# Altium schematic sheet LM-008.SchDoc(LM-008)
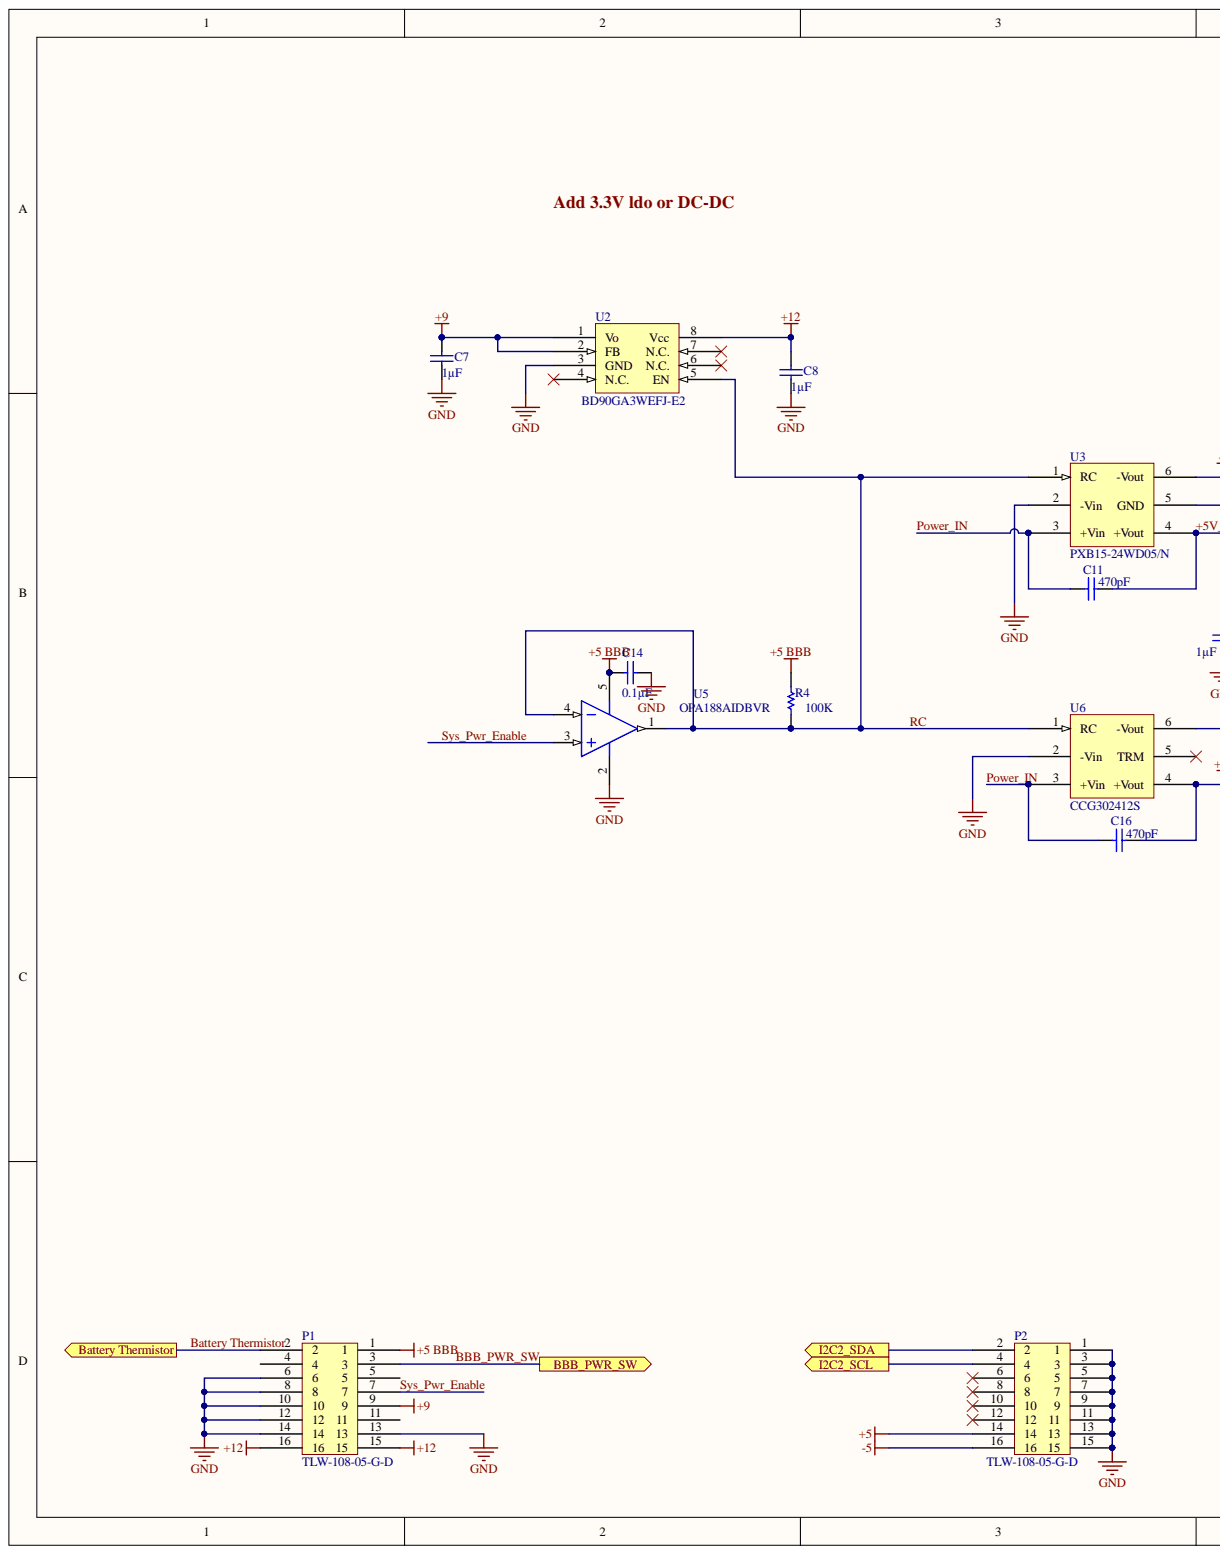
[diagram p0: LM-008.SchDoc, left]
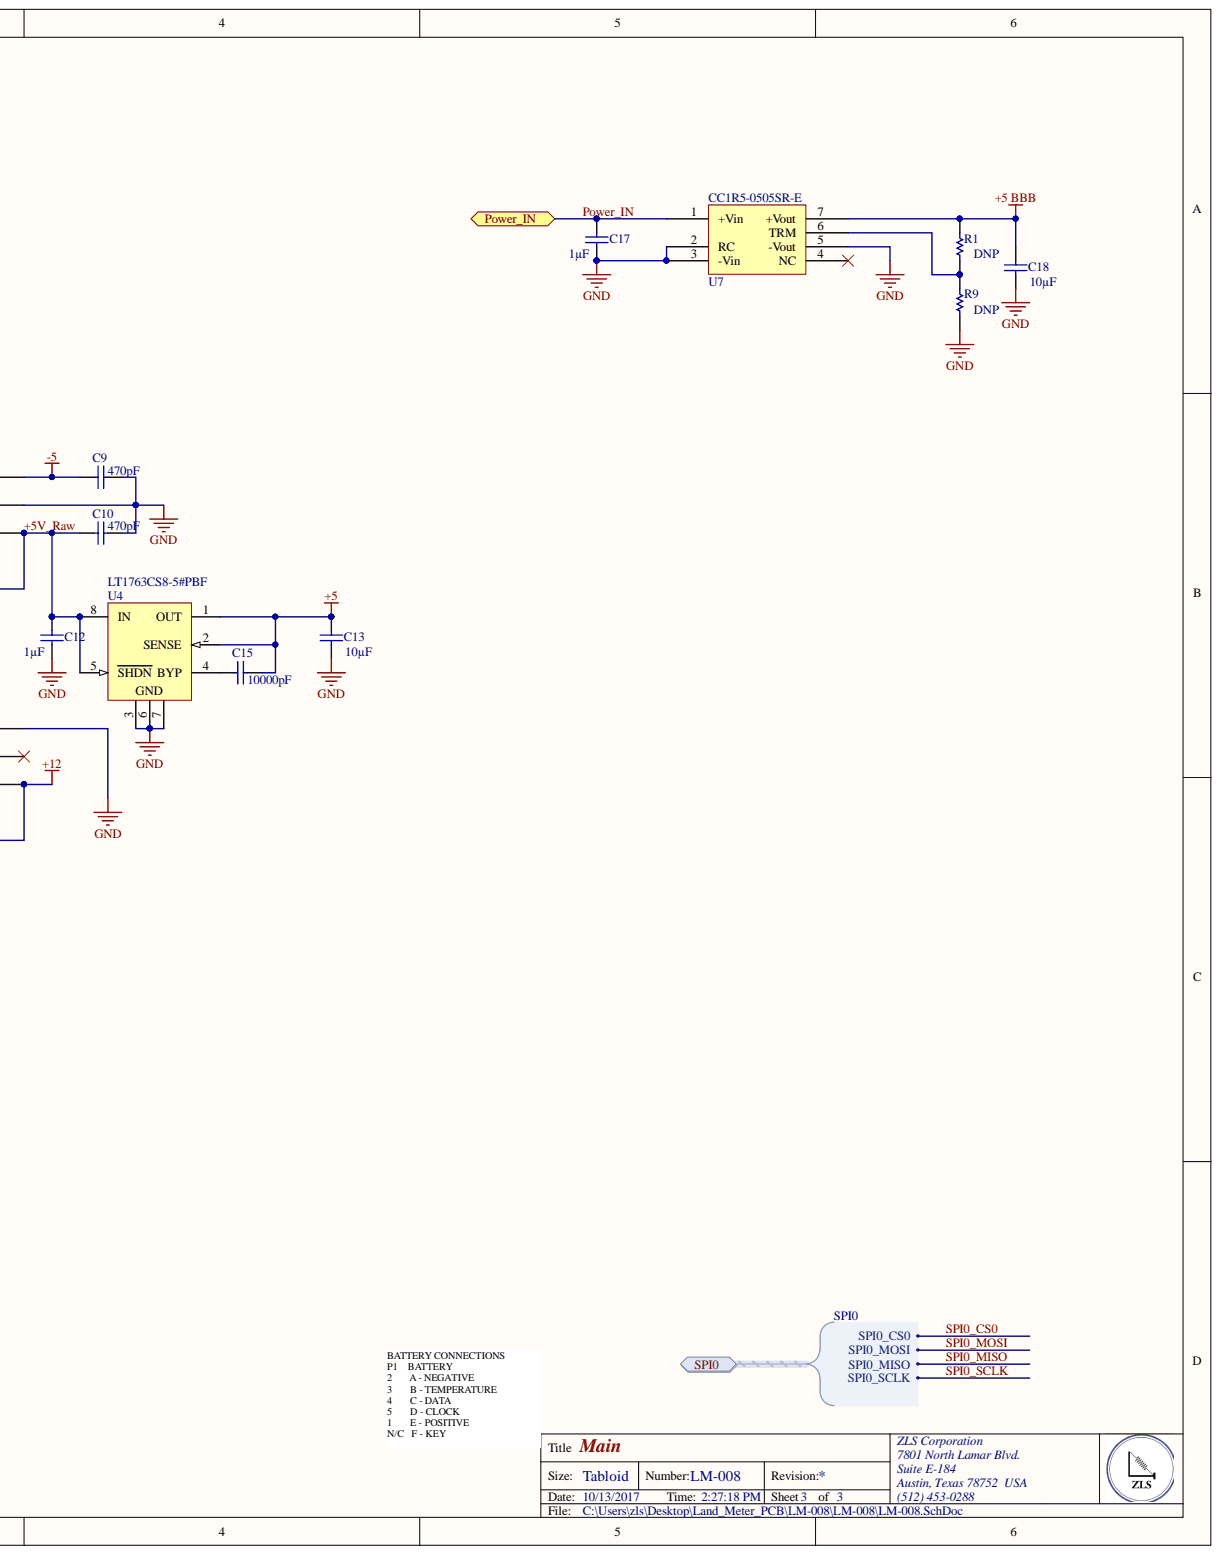
[diagram p1: LM-008.SchDoc, right]

## Parts
C7  Capacitance: 1µF  [CAPC1206(3216)130_N]  (hidden comment: CAP CER 1UF 50V X7R 1210)
C8  Capacitance: 1µF  [CAPC1206(3216)130_N]  (hidden comment: CAP CER 1UF 50V X7R 1210)
C9  Capacitance: 470pF  [CAPC1812(4532)230_M]  (hidden comment: CAP CER 470PF 3KV X7R 1812)
C10  Capacitance: 470pF  [CAPC1812(4532)230_M]  (hidden comment: CAP CER 470PF 3KV X7R 1812)
C11  Capacitance: 470pF  [CAPC1812(4532)230_M]  (hidden comment: CAP CER 470PF 3KV X7R 1812)
C12  Capacitance: 1µF  [CAPC1210(3225)100_L]  (hidden comment: CAP CER 1UF 50V X7R 1210)
C13  Capacitance: 10µF  [CAPC0805(2012)145_N]  (hidden comment: CAP CER 10UF 10V X7R 0805)
C14  Capacitance: 0.1µF  [CAPC0805(2012)145_N]  (hidden comment: CAP CER 0.1UF 16V X7R 0805)
C15  Capacitance: 10000pF  [CAPC0805(2012)145_N]  (hidden comment: CAP CER 10000PF 25V X7R 0805)
C16  Capacitance: 470pF  [CAPC1812(4532)230_M]  (hidden comment: CAP CER 470PF 3KV X7R 1812)
C17  Capacitance: 1µF  [CAPC1210(3225)100_L]  (hidden comment: CAP CER 1UF 50V X7R 1210)
C18  Capacitance: 10µF  [CAPC0805(2012)145_N]  (hidden comment: CAP CER 10UF 10V X7R 0805)
P1  TLW-108-05-G-D  [TLW-108-05-G-D]
P2  TLW-108-05-G-D  [TLW-108-05-G-D]
R1  DNP  [RESC0402(1005)_L]
R4  100K  [RESC0402(1005)_L]
R9  DNP  [RESC0402(1005)_L]
U2  BD90GA3WEFJ-E2  [TSOP127P600X100-8N]
U3  PXB15-24WD05/N  [PXB15-24WD05/N]
U4  LT1763CS8-5#PBF  [LT-S8-8_M]
U5  OPA188AIDBVR  [DBV0005A_M]
U6  CCG302412S  [CCG30]
U7  CC1R5-0505SR-E  [CC1R5-0505SR-E]

## Ports
Battery Thermistor, BBB_PWR_SW, I2C2_SCL, I2C2_SDA, Power_IN, SPI0, SPI0.SPI0_CS0, SPI0.SPI0_MISO, SPI0.SPI0_MOSI, SPI0.SPI0_SCLK

## Nets
+5: C13.2 C15.1 P2.14 U4.1(OUT) U4.2(SENSE)
+5 BBB: C14.1 C18.2 P1.1 R1.2 R4.2 U5.5 U7.7(+Vout)
+9: C7.2 P1.9 U2.1(Vo) U2.2(FB)
+12: C8.2 C16.2 P1.15 P1.16 U2.8(Vcc) U6.4(+Vout)
-5: C9.1 P2.16 U3.6(-Vout)
GND: C7.1 C8.1 C9.2 C10.2 C12.1 C13.1 C14.2 C17.1 C18.1 P1.6 P1.8 P1.10 P1.12 P1.13 P1.14 P2.1 P2.3 P2.5 P2.7 P2.9 P2.11 P2.13 P2.15 R9.1 U2.3(GND) U3.2(-Vin) U3.5(GND) U4.3 U4.6 U4.7 U5.2 U6.2(-Vin) U6.6(-Vout) U7.2(RC) U7.3(-Vin) U7.5(-Vout)
+5V_Raw: C10.1 C11.2 C12.2 U3.4(+Vout) U4.5(SHDN) U4.8(IN)
Battery Thermistor: P1.2 ; port Battery Thermistor
BBB_PWR_SW: P1.3 ; port BBB_PWR_SW
I2C2_SCL: P2.4 ; port I2C2_SCL
I2C2_SDA: P2.2 ; port I2C2_SDA
Power_IN: C11.1 C16.1 C17.2 U3.3(+Vin) U6.3(+Vin) U7.1(+Vin) ; port Power_IN
RC: R4.1 U2.5(EN) U3.1(RC) U5.1 U5.4 U6.1(RC)
Sys_Pwr_Enable: P1.7 U5.3
NetC15_2: C15.2 U4.4(BYP)
NetR1_1: R1.1 R9.2 U7.6(TRM)
SPI0_CS0: ; port SPI0
SPI0_MISO: ; port SPI0
SPI0_MOSI: ; port SPI0
SPI0_SCLK: ; port SPI0

Not connected: P1 4 5 11; P2 6 8 10 12; U2 4(N.C.) 6(N.C.) 7(N.C.); U6 5(TRM); U7 4(NC)

## Library data of the parts
C7 C8 = CMP-1037-04913-1: Manufacturer Part Number: GRM32RR71H105KA01L; Manufacturer: Murata Electronics North America; Tolerance: ±10%; Voltage - Rated: 50V; Thickness (Max): 0.079" (2.00mm); Temperature Coefficient: X7R; Supplier Part Number 1: 490-1863-6-ND; Supplier 1: Digi-Key; Stock 1: 66890; Size / Dimension: 0.126" L x 0.098" W (3.20mm x 2.50mm); RoHS: RoHS Compliant; Ratings: -; Pricing 1: 1=0.35, 10=0.242, 100=0.1449, 500=0.10868 (USD); Part Status: Active; Packaging: Digi-Reel®; Package / Case: 1210 (3225 Metric); Operating Temperature: -55°C ~ 125°C; Mounting Type: Surface Mount, MLCC; Library Name: Altium Content Vault; Lead Style: -; Lead Spacing: -; Height - Seated (Max): -; Features: -; Description: CAP CER 1UF 50V X7R 1210; ComponentLink1URL: http://search.murata.co.jp/Ceramy/image/img/A01X/G101/ENG/GRM32RR71H105KA01-01.pdf; ComponentLink1Description: http://search.murata.co.jp/Ceramy/image/img/A01X/G101/ENG/GRM32RR71H105KA01-01.pdf; Component Kind: Standard; Category: Capacitors; Applications: General Purpose
C9-C11 C16 = CMP-1040-00561-1: Manufacturer Part Number: C1812C471KHRACTU; Manufacturer: Kemet; Tolerance: 10%; Width: 0.126inch; Voltage Rating (DC): 3kV; Voltage Rating: 3kV; Thickness: 0.071inch; Supplier Part Number 1: 399-7289-6-ND; Supplier 1: Digi-Key; Stock 1: 2705; Pricing 1: 1=0.88, 10=0.666, 100=0.4761 (USD); Packaging: Tape and Reel; Package Quantity: 1; Min Operating Temperature: -55°C; Max Operating Temperature: 125°C; Library Name: Altium Content Vault; Length: 0.177inch; Lead Free: Lead Free; Description: CAP CER 470PF 3KV X7R 1812; ComponentLink1URL: http://www.kemet.com/docfinder?Partnumber=C1812C471KHRACTU; ComponentLink1Description: http://www.kemet.com/docfinder?Partnumber=C1812C471KHRACTU; Component Kind: Standard; Case Code (Metric): 4532; Case Code (Imperial): 1812; Case/Package: 1812
C12 C17 = CMP-1038-00632-1: Manufacturer Part Number: GRM32RR71H105KA01L; Manufacturer: Murata Electronics North America; Tolerance: ±10%; Voltage - Rated: 50V; Thickness (Max): 0.079" (2.00mm); Temperature Coefficient: X7R; Supplier Part Number 1: 490-1863-6-ND; Supplier 1: Digi-Key; Stock 1: 66890; Size / Dimension: 0.126" L x 0.098" W (3.20mm x 2.50mm); RoHS: RoHS Compliant; Ratings: -; Pricing 1: 1=0.35, 10=0.242, 100=0.1449, 500=0.10868 (USD); Part Status: Active; Packaging: Digi-Reel®; Package / Case: 1210 (3225 Metric); Operating Temperature: -55°C ~ 125°C; Mounting Type: Surface Mount, MLCC; Library Name: Altium Content Vault; Lead Style: -; Lead Spacing: -; Height - Seated (Max): -; Features: -; Description: CAP CER 1UF 50V X7R 1210; ComponentLink1URL: http://search.murata.co.jp/Ceramy/image/img/A01X/G101/ENG/GRM32RR71H105KA01-01.pdf; ComponentLink1Description: http://search.murata.co.jp/Ceramy/image/img/A01X/G101/ENG/GRM32RR71H105KA01-01.pdf; Component Kind: Standard; Category: Capacitors; Applications: General Purpose
C13 C18 = CMP-1036-04795-1: Manufacturer Part Number: GCM21BR71A106KE22L; Manufacturer: Murata Electronics North America; Tolerance: ±10%; Voltage - Rated: 10V; Thickness (Max): 0.055" (1.40mm); Temperature Coefficient: X7R; Supplier Part Number 1: 490-10674-6-ND; Supplier 1: Digi-Key; Stock 1: 136726; Size / Dimension: 0.079" L x 0.049" W (2.00mm x 1.25mm); RoHS: RoHS Compliant; Ratings: AEC-Q200; Pricing 1: 1=0.49, 10=0.347, 100=0.2282, 500=0.16906, 1000=0.14369 (USD); Part Status: Active; Packaging: Digi-Reel®; Package / Case: 0805 (2012 Metric); Operating Temperature: -55°C ~ 125°C; Mounting Type: Surface Mount, MLCC; Library Name: Altium Content Vault; Lead Style: -; Lead Spacing: -; Height - Seated (Max): -; Features: -; Description: CAP CER 10UF 10V X7R 0805; ComponentLink1URL: http://search.murata.co.jp/Ceramy/image/img/A01X/G101/ENG/GCM21BR71A106KE22-01.pdf; ComponentLink1Description: http://search.murata.co.jp/Ceramy/image/img/A01X/G101/ENG/GCM21BR71A106KE22-01.pdf; Component Kind: Standard; Category: Capacitors; Applications: Automotive
C14 = CMP-1036-04795-1: Manufacturer Part Number: GRM219R71C104KA01D; Manufacturer: Murata Electronics North America; Voltage - Rated: 25V; Tolerance: ±10%; Thickness (Max): 0.053" (1.35mm); Temperature Coefficient: X7R; Supplier Part Number 2: 490-1683-6-ND; Supplier Part Number 1: 490-1673-6-ND; Supplier 2: Digi-Key; Stock 2: 245331; Size / Dimension: 0.079" L x 0.049" W (2.00mm x 1.25mm); RoHS: RoHS Compliant; Ratings: -; Pricing 2: 1=0.14, 10=0.098, 100=0.0462, 500=0.0324, 1000=0.02683 (USD); Part Status: Active; Packaging: Digi-Reel®; Package / Case: 0805 (2012 Metric); Operating Temperature: -55°C ~ 125°C; Mounting Type: Surface Mount, MLCC; Library Name: Altium Content Vault; Lead Style: -; Lead Spacing: -; Height - Seated (Max): -; Features: -; Description: CAP CER 0.1UF 16V X7R 0805; ComponentLink1URL: http://www.murata.com/~/media/webrenewal/support/library/catalog/products/capacitor/mlcc/c02e.ashx; ComponentLink1Description: http://www.murata.com/~/media/webrenewal/support/library/catalog/products/capacitor/mlcc/c02e.ashx; Component Kind: Standard; Category: Capacitors; Applications: General Purpose
C15 = CMP-1036-04795-1: Manufacturer Part Number: GRM216R71E103KA01D; Manufacturer: Murata Electronics North America; Tolerance: ±10%; Voltage - Rated: 25V; Thickness (Max): 0.028" (0.70mm); Temperature Coefficient: X7R; Supplier Part Number 1: 490-12748-6-ND; Supplier 1: Digi-Key; Stock 1: 13127; Size / Dimension: 0.079" L x 0.049" W (2.00mm x 1.25mm); RoHS: RoHS Compliant; Ratings: -; Pricing 1: 1=0.11, 10=0.077, 100=0.0343, 500=0.02452, 1000=0.01926 (USD); Part Status: Active; Packaging: Digi-Reel®; Package / Case: 0805 (2012 Metric); Operating Temperature: -55°C ~ 125°C; Mounting Type: Surface Mount, MLCC; Library Name: Altium Content Vault; Lead Style: -; Lead Spacing: -; Height - Seated (Max): -; Features: -; Description: CAP CER 10000PF 25V X7R 0805; ComponentLink1URL: http://www.murata.com/~/media/webrenewal/support/library/catalog/products/capacitor/mlcc/c02e.ashx; ComponentLink1Description: http://www.murata.com/~/media/webrenewal/support/library/catalog/products/capacitor/mlcc/c02e.ashx; Component Kind: Standard; Category: Capacitors; Applications: General Purpose
P1 P2 = TLW-108-05-G-D: CONN HEADER .100" 16POS DL GOLD; Manufacturer: Samtec Inc.; Style: Board to Board or Cable; Shrouding: Unshrouded; Row Spacing - Mating: 0.100" (2.54mm); Overall Contact Length: 0.335" (8.51mm); Number of Positions Loaded: All; Number of Positions: 16; Current Rating: 5.2A per Contact; Contact Length - Post: 0.170" (4.32mm); Contact Length - Mating: 0.105" (2.67mm); Voltage Rating: -; Termination: Solder; Supplier Part Number 1: SAM1094-08-ND; Supplier 1: Digi-Key; Stock 1: 0; RoHS: RoHS Compliant; Pricing 1: 1=4.48, 10=4.006, 100=3.2987, 500=2.60756, 1000=2.23842, 5000=2.04207, 10000=1.96353 (USD); Pitch - Mating: 0.100" (2.54mm); Part Status: Active; Packaging: Bulk; Operating Temperature: -55°C ~ 125°C; Number of Rows: 2; Mounting Type: Through Hole; Material Flammability Rating: -; Mated Stacking Heights: -; Manufacturer Part Number: TLW-108-05-G-D; Insulation Material: Liquid Crystal Polymer (LCP); Insulation Height: 0.060" (1.52mm); Insulation Color: Black; Ingress Protection: -; Features: -; Fastening Type: Push-Pull; Contact Type: Male Pin; Contact Shape: Square; Contact Material: Phosphor Bronze; Contact Finish Thickness - Post: Flash; Contact Finish Thickness - Mating: 10µin (0.25µm); Contact Finish - Post: Gold; Contact Finish - Mating: Gold; Connector Type: Header; ComponentLink1URL: http://suddendocs.samtec.com/prints/tlw-mkt.pdf; ComponentLink1Description: http://suddendocs.samtec.com/prints/tlw-mkt.pdf; Component Kind: Standard; Category: Connectors, Interconnects; Applications: -; Library Name: ZLS_Schematic_lib.SchLib
R1 R9 = CMP-1011-00927-1: TBD; Tolerance: ±1%; Temperature Coefficient: ±100ppm/°C; Supplier Part Number 1: YAG3220CT-ND; Supplier Device Package: 0402; Library Name: Altium Content Vault; Component Kind: Standard
R4 = CMP-1011-00927-1: Tolerance: ±1%; Temperature Coefficient: ±100ppm/°C; Supplier Part Number 1: YAG3220CT-ND; Supplier Device Package: 0402; Library Name: Altium Content Vault; Component Kind: Standard
U2 = BD90GA3WEFJ-E2: IC REG LDO 9V 0.3A 8HTSOP-J; Manufacturer: Rohm Semiconductor; Voltage - Input: Up to 14V; Voltage - Dropout (Typical): 0.6V @ 300mA; Regulator Topology: Positive Fixed; Current - Output: 300mA; Voltage - Output: 9V; Supplier Part Number 1: BD90GA3WEFJ-E2CT-ND; Supplier Device Package: 8-HTSOP-J; Supplier 1: Digi-Key; Stock 1: 4781; RoHS: RoHS Compliant; Pricing 1: 1=0.69, 10=0.606, 25=0.5364, 100=0.4672, 250=0.40664, 500=0.34608, 1000=0.27686 (USD); Part Status: Active; Packaging: Cut Tape (CT); Package / Case: 8-SOIC (0.154", 3.90mm Width) Exposed Pad; Operating Temperature: -25°C ~ 85°C; Mounting Type: Surface Mount; Manufacturer Part Number: BD90GA3WEFJ-E2; Current - Limit (Min): -; ComponentLink1URL: http://rohmfs.rohm.com/en/products/databook/datasheet/ic/power/linear_regulator/bdxxga3wefj-e.pdf; ComponentLink1Description: http://rohmfs.rohm.com/en/products/databook/datasheet/ic/power/linear_regulator/bdxxga3wefj-e.pdf; Component Kind: Standard; Category: Integrated Circuits (ICs); Library Name: ZLS_Schematic_lib.SchLib
U3 = CCG302412S: IC CONV DC-DC 15W DUAL 5V PCB; Manufacturer 1: TDK-Lambda Americas Inc.; Voltage - Output 2: -5V; Voltage - Isolation: 1.6kV (1600V); Voltage - Input (Min): 9V; Voltage - Input (Max): 36V; Number of Outputs: 2; Efficiency: 85%; Current - Output (Max): 1.5A, 1.5A; Category 1: Power Supplies - Board Mount; Voltage - Output 3: -; Voltage - Output 1: 5V; Type: Isolated Module; Supplier Part Number 1: 285-1902-ND; Supplier 1: Digi-Key; Stock 1: 28; Size / Dimension: 1.00" L x 1.00" W x 0.39" H (25.4mm x 25.4mm x 9.9mm); RoHS 1: RoHS Compliant; Pricing 1: 1=61.61, 10=59.59 (USD); Power (Watts): 15W; Part Status: Active; Packaging: Tube; Package / Case: 6-DIP Module; Operating Temperature: -40°C ~ 85°C; Mounting Type: Through Hole; Manufacturer Part Number 1: PXB15-24WD05/N; Features: Remote On/Off, OCP, OVP, SCP; Description 1: IC CONV DC-DC 15W DUAL 5V PCB; ComponentLink1URL: http://us.tdk-lambda.com/ftp/AppNotes/pxb15w-d_appnote.pdf; ComponentLink1Description: http://us.tdk-lambda.com/ftp/AppNotes/pxb15w-d_appnote.pdf; Component Kind: Standard; Applications: ITE (Commercial); Library Name: ZLS_LIB.SchLib
U4 = CMP-0476-01685-1: 500 mA, Low Noise, LDO Micropower Regulator, 1.8 to 20 V Vin, 5 V Vout, 8-pin SOIC (S8-8), -40 to 125 degC, Pb-Free; Manufacturer: Linear Technology; Vin Min(V): 1.8; Vin Max(V): 20; Shutdown Current(uA): 1; PSRR(dB): 65; Output Voltage: 5 V; Isupply(mA): 0.03; Iout(mA): 500; Dropout Voltage(V): 0.3; Discrete Pass Element: no; RoHS: TRUE; PartNumber: LT1763CS8-5#PBF; PackageVersion: Rev. G, 03/2012; PackageReference: S8-8; PackageDescription: 8-Pin Plastic Small Outline (Narrow .150 Inch), Body 4.9 x 3.9 mm, Pitch 1.27 mm; Number of Outputs: 1; Noise(uV): 20; Mounting Technology: Surface Mount; Library Name: Altium Content Vault; DatasheetVersion: Rev. G; ComponentLink3URL: http://cds.linear.com/docs/en/packaging/05081610_G_SO8.pdf; ComponentLink3Description: Package Specification; ComponentLink2URL: http://cds.linear.com/docs/en/datasheet/1763fg.pdf; ComponentLink2Description: Datasheet; ComponentLink1URL: http://www.linear.com/; ComponentLink1Description: Manufacturer URL; Component Kind: Standard
U5 = CMP-0809-00005-2: Precision, Low Noise, Rail-to-Rail Output, Zero-Drift Operational Amplifier, 4 to 36 V, -40 to 125 degC, 5-pin SOT23 (DBV5), Green (RoHS & no Sb/Br); Manufacturer: Texas Instruments; Total Supply Voltage(Min)(+5V is 5, +/-5V is 10): 4; Total Supply Voltage(Max)(+5V is 5, +/-5V is 10): 36; SubFamily: Zero Drift Precision Amplifier; Slew Rate(Typ)(V/us): 0.8; Rating: Catalog; Offset Drift(Typ)(uV/C): 0.03; Number of Channels: 1; Iq per channel(Max)(mA): 0.51; GBW(Typ)(MHz): 2; CMRR(Min)(dB): 120; PartNumber: OPA188AIDBVR; PackageVersion: 4073253-4/K, 03/2006; PackageReference: DBV0005A; PackageDescription: 5-Pin Plastic Small Outline, Body 1.6 x 2.9 mm, Pitch 0.95 mm; Mounting Technology: Surface Mount; Library Name: Altium Content Vault; Eco-Plan: Green (RoHS & no Sb/Br); DatasheetVersion: SBOS642A; ComponentLink3URL: http://www.ti.com/litv/pdf/mpds018i; ComponentLink3Description: Package Specification; ComponentLink2URL: http://www.ti.com/lit/ds/symlink/opa188.pdf; ComponentLink2Description: Datasheet; ComponentLink1URL: http://www.ti.com/; ComponentLink1Description: Manufacturer URL; Component Kind: Standard; Code_JEDEC: MO-178
U6 = CCG302412S: DC/DC CONVERTER 12V 2.5A PCB; Manufacturer 1: TDK-Lambda Americas Inc; Voltage - Isolation: 1.5kV (1500V); Voltage - Input (Min): 9V; Voltage - Input (Max): 36V; Power (Watts) - Max: 30W; Number of Outputs: 1; Efficiency: 89%; Category 1: Power Supplies - Board Mount; Voltage - Output 3: -; Voltage - Output 2: -; Voltage - Output 1: 12V; Type: Isolated Module; Supplier Part Number 1: 285-2419-ND; Supplier 1: Digi-Key; Stock 1: 30; Size / Dimension: 1.00" L x 1.00" W x 0.39" H (25.4mm x 25.4mm x 9.9mm); RoHS 1: RoHS Compliant; Pricing 1: 1=43.63, 10=42.95 (USD); Power (Watts) - Manufacture Series: 30W; Packaging: Tube; Package / Case: 6-DIP Module (6 Leads); Operating Temperature: -40°C ~ 85°C; Mounting Type: Through Hole; Manufacturer Part Number 1: CCG302412S; Features: Remote On/Off, OCP; Description 1: DC/DC CONVERTER 12V 2.5A PCB; Current - Output (Max): 2.5A; ComponentLink1URL: http://www.us.tdk-lambda.com/ftp/specs/ccg30.pdf; ComponentLink1Description: http://www.us.tdk-lambda.com/ftp/specs/ccg30.pdf; Component Kind: Standard; Library Name: ZLS_LIB.SchLib
U7 = CC1R5-0505SR-E: CONV DC/DC 1.5W SNGL 5V 5V SMD; Manufacturer: TDK-Lambda Americas Inc.; Voltage - Isolation: 500V; Voltage - Input (Min): 4.5V; Voltage - Input (Max): 9V; Power (Watts): 1W; Number of Outputs: 1; Current - Output (Max): 300mA; Voltage - Output 3: -; Voltage - Output 2: -; Voltage - Output 1: 5V; Type: Isolated Module; Supplier Part Number 1: 445-3267-ND; Supplier 1: Digi-Key; Stock 1: 174; Size / Dimension: 0.65" L x 0.65" W x 0.35" H (16.5mm x 16.6mm x 8.8mm); RoHS: RoHS Compliant; Pricing 1: 1=9.7, 10=9.24 (USD); Part Status: Active; Packaging: Tray; Package / Case: 7-SMD Module; Operating Temperature: -40°C ~ 85°C; Mounting Type: Surface Mount; Manufacturer Part Number: CC1R5-0505SR-E; Features: -; Efficiency: -; ComponentLink1URL: http://www.us.tdk-lambda.com/ftp/specs/CC1R5-xR-E_spc_en.pdf; ComponentLink1Description: http://www.us.tdk-lambda.com/ftp/specs/CC1R5-xR-E_spc_en.pdf; Component Kind: Standard; Category: Power Supplies - Board Mount; Applications: ITE (Commercial); Library Name: ZLS_Schematic_lib.SchLib
